# Altium schematic sheet Sheet1.SchDoc(Sheet1)
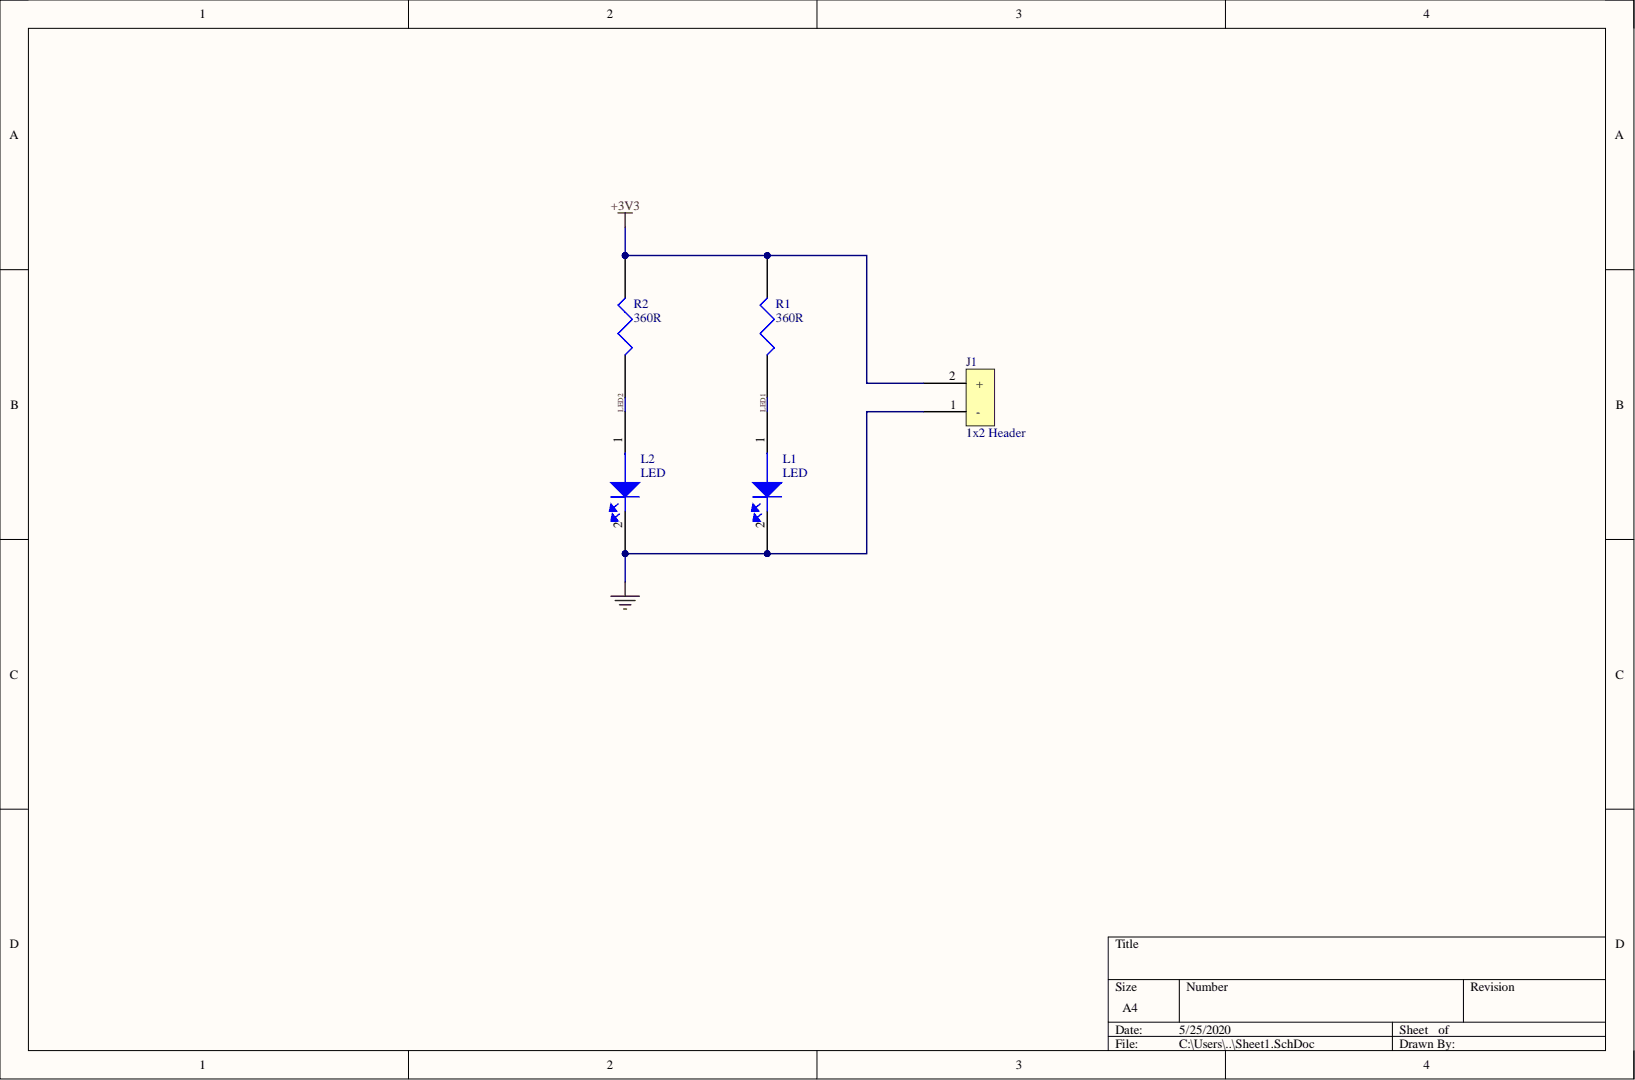
[diagram p0: Sheet1.SchDoc]

## Parts
J1  1x2 Header  [header1x2]
L1  LED  [LED]
L2  LED  [LED]
R1  360R  [R0805]
R2  360R  [R0805]

## Nets
+3V3: J1.2 R1.2 R2.2
GND: J1.1 L1.2 L2.2
LED1: L1.1 R1.1
LED2: L2.1 R2.1

## Library data of the parts
J1 = 22-23-2021: Connector Wire to Board Header 2 Position 2.54mm Solder Stra; Manufacturer: Molex; Approvals: UL,CSA; Categori: Connectors, Interconnects; Contact Resistance: 10mR; Current Rating: 4A; Gender: Male; Glow Wire Compliant: Non-compliant; Insulation Resistance: 500GR; Number of Circuits: 2; Voltage Rating: 250V; Category: Headers and Wire Housings; Color: White; Component Kind: Standard; ComponentLink1Description: https://datasheet.ciiva.com/19454/232266-19454304.pdf?src-supplier=Jameco; ComponentLink1URL: https://datasheet.ciiva.com/19454/232266-19454304.pdf?src-supplier=Jameco; ComponentLink2Description: https://datasheet.ciiva.com/5150/0022232021-pcb-headers-227096-5150681.pdf?src-supplier=Mouser; ComponentLink2URL: https://datasheet.ciiva.com/5150/0022232021-pcb-headers-227096-5150681.pdf?src-supplier=Mouser; ComponentLink3Description: https://datasheet.ciiva.com/22683/1834442-22683561.pdf?src-supplier=Element14; ComponentLink3URL: https://datasheet.ciiva.com/22683/1834442-22683561.pdf?src-supplier=Element14; ComponentLink4Description: https://datasheet.ciiva.com/11746/0900766b80d8f4d1-11746058.pdf?src-supplier=RSComponents; ComponentLink4URL: https://datasheet.ciiva.com/11746/0900766b80d8f4d1-11746058.pdf?src-supplier=RSComponents; ComponentLink5Description: https://datasheet.ciiva.com/30253/114200022232021-pcb-headers-30253002.pdf?src-supplier=Onlinecomponents.com; ComponentLink5URL: https://datasheet.ciiva.com/30253/114200022232021-pcb-headers-30253002.pdf?src-supplier=Onlinecomponents.com; ComponentLink6Description: https://datasheet.ciiva.com/9468/0022232021-pcb-headers-9468476.pdf?src-supplier=Avnet; ComponentLink6URL: https://datasheet.ciiva.com/9468/0022232021-pcb-headers-9468476.pdf?src-supplier=Avnet; ComponentLink7Description: https://datasheet.ciiva.com/18453/70190606-18453638.pdf?src-supplier=Allied+Electronics; ComponentLink7URL: https://datasheet.ciiva.com/18453/70190606-18453638.pdf?src-supplier=Allied+Electronics; ComponentLink8Description: https://datasheet.ciiva.com/16658/0022232021-pcb-headers-16658167.pdf?src-supplier=Avnet+Europe; ComponentLink8URL: https://datasheet.ciiva.com/16658/0022232021-pcb-headers-16658167.pdf?src-supplier=Avnet+Europe; Contact Material: Brass; Contact Plating: Tin,Copper; Depth: 6.35mm; Flammability Rating: UL94 V-0; Halogen Free: Low Halogen; Height: 10.67mm; Housing Color: White; Housing Material: Nylon; Lead Free: Lead Free; Length: 5.08mm; Library Name: Schlib1.SchLib; Manufacturer Part Number: 22-23-2021; Max Current Rating: 4A; Max Operating Temperature: 75°C; Min Operating Temperature: 0°C; Mount: Through Hole; Number of Contacts: 2; Number of Positions: 2; Number of Rows: 1; Orientation: Straight; Package Quantity: 3000; Packaging: Bulk; Pitch: 2.54mm; Pricing 1: 1=0,17000, 10=0,16100, 100=0,11150, 500=0,09438, 1000=0,07722, 5000=0,06864, 10000=0,06221 (USD); Radiation Hardening: No; REACH SVHC: Unknown; RoHS: Yes; Stock 1: 56479; Supplier 1: Digi-Key; Supplier Part Number 1: 900-0022232021-ND; Termination: Through Hole; Voltage Rating (DC): 250V
L1 L2 = LNJ926W8CRA: CHIPLED, 0603, BLUE, 11.5MCD, 470NM; Manufacturer: Panasonic SSG; Categorie: Optoelectronics; Forward Current: 5mA; Forward Voltage: 2.9V; Lens Style: Rectangular; Number of LEDs: 1; Reverse Voltage: 5V; Case Code (Imperial): 0603; Case Code (Metric): 1608; Case/Package: 0603; Category: LEDs; Color: Blue; Component Kind: Standard; ComponentLink1Description: https://datasheet.ciiva.com/4688/lnj926w8cra-cek-226062-4688285.pdf?src-supplier=Mouser; ComponentLink1URL: https://datasheet.ciiva.com/4688/lnj926w8cra-cek-226062-4688285.pdf?src-supplier=Mouser; ComponentLink2Description: https://datasheet.ciiva.com/8028/lnj926w8cra-cek-8028642.pdf?src-supplier=Element14; ComponentLink2URL: https://datasheet.ciiva.com/8028/lnj926w8cra-cek-8028642.pdf?src-supplier=Element14; ComponentLink3Description: https://datasheet.ciiva.com/11775/0900766b80f0a8cd-11775371.pdf?src-supplier=RSComponents; ComponentLink3URL: https://datasheet.ciiva.com/11775/0900766b80f0a8cd-11775371.pdf?src-supplier=RSComponents; Dominant Wavelength: 470nm; Height: 0.35mm; Illumination Color: Blue; Lead Free: Lead Free; Length: 1.6mm; Library Name: Schlib1.SchLib; Luminous Intensity: 11.5mcd; Manufacturer Part Number: LNJ926W8CRA; Max Operating Temperature: 85°C; Min Operating Temperature: -30°C; Mount: Surface Mount; Number of Pins: 2; Package Quantity: 5000; Packaging: Tape and Reel; Pricing 1: 1=0,34000, 10=0,26300, 100=0,21780, 500=0,19932, 1000=0,19272 (USD); REACH SVHC: Yes; RoHS: Yes; Stock 1: 11665; Supplier 1: Digi-Key; Supplier Part Number 1: P13484CT-ND; Test Current: 5mA; Wavelength: 470nm; Width: 0.8mm
R1 R2 = CRCW0805360RFKEA: Res Thick Film 0805 360 Ohm 1% 0.125W(1/8W) ±100ppm/C Molded SMD Automotive Paper T/R; Manufacturer: Vishay; Categorie: Resistors; Max Power Dissipation: 125mW; Temperature Coefficient: 100ppm/°C; Voltage Rating: 150V; Case Code (Imperial): 0805; Case Code (Metric): 2012; Case/Package: 0805; Category: Chip SMD Resistors; Component Kind: Standard; ComponentLink1Description: https://datasheet.ciiva.com/2467/dcrcwe3-2467773.pdf?src-supplier=Digi-Key; ComponentLink1URL: https://datasheet.ciiva.com/2467/dcrcwe3-2467773.pdf?src-supplier=Digi-Key; Composition: Thick Film; Contact Plating: Tin; Depth: 1.25mm; Features: Automotive AEC-Q200; Height: 0.45mm; Lead Free: Lead Free; Length: 2mm; Library Name: Schlib1.SchLib; Manufacturer Part Number: CRCW0805360RFKEA; Max Operating Temperature: 155°C; Military Standard: Not; Min Operating Temperature: -55°C; Number of Pins: 2; Number of Terminals: 2; Number of Terminations: 2; Package Quantity: 10; Packaging: Tape and Reel; Power Rating: 125mW; Pricing 1: 1=0,10000, 10=0,06200, 100=0,02540, 1000=0,01142, 2500=0,00991 (USD); Radiation Hardening: No; REACH SVHC: Unknown; Resistance: 360R; RoHS: Yes; Stock 1: 19728; Supplier 1: Digi-Key; Supplier Part Number 1: 541-360CCT-ND; Termination: SMD/SMT; Tolerance: 1%; Voltage Rating (DC): 150V; Weight: 0.000194oz; Width: 0.049inch
